# Altium schematic sheet H-Bridge using MOSFET.SchDoc("H-Bridge using MOSFET")
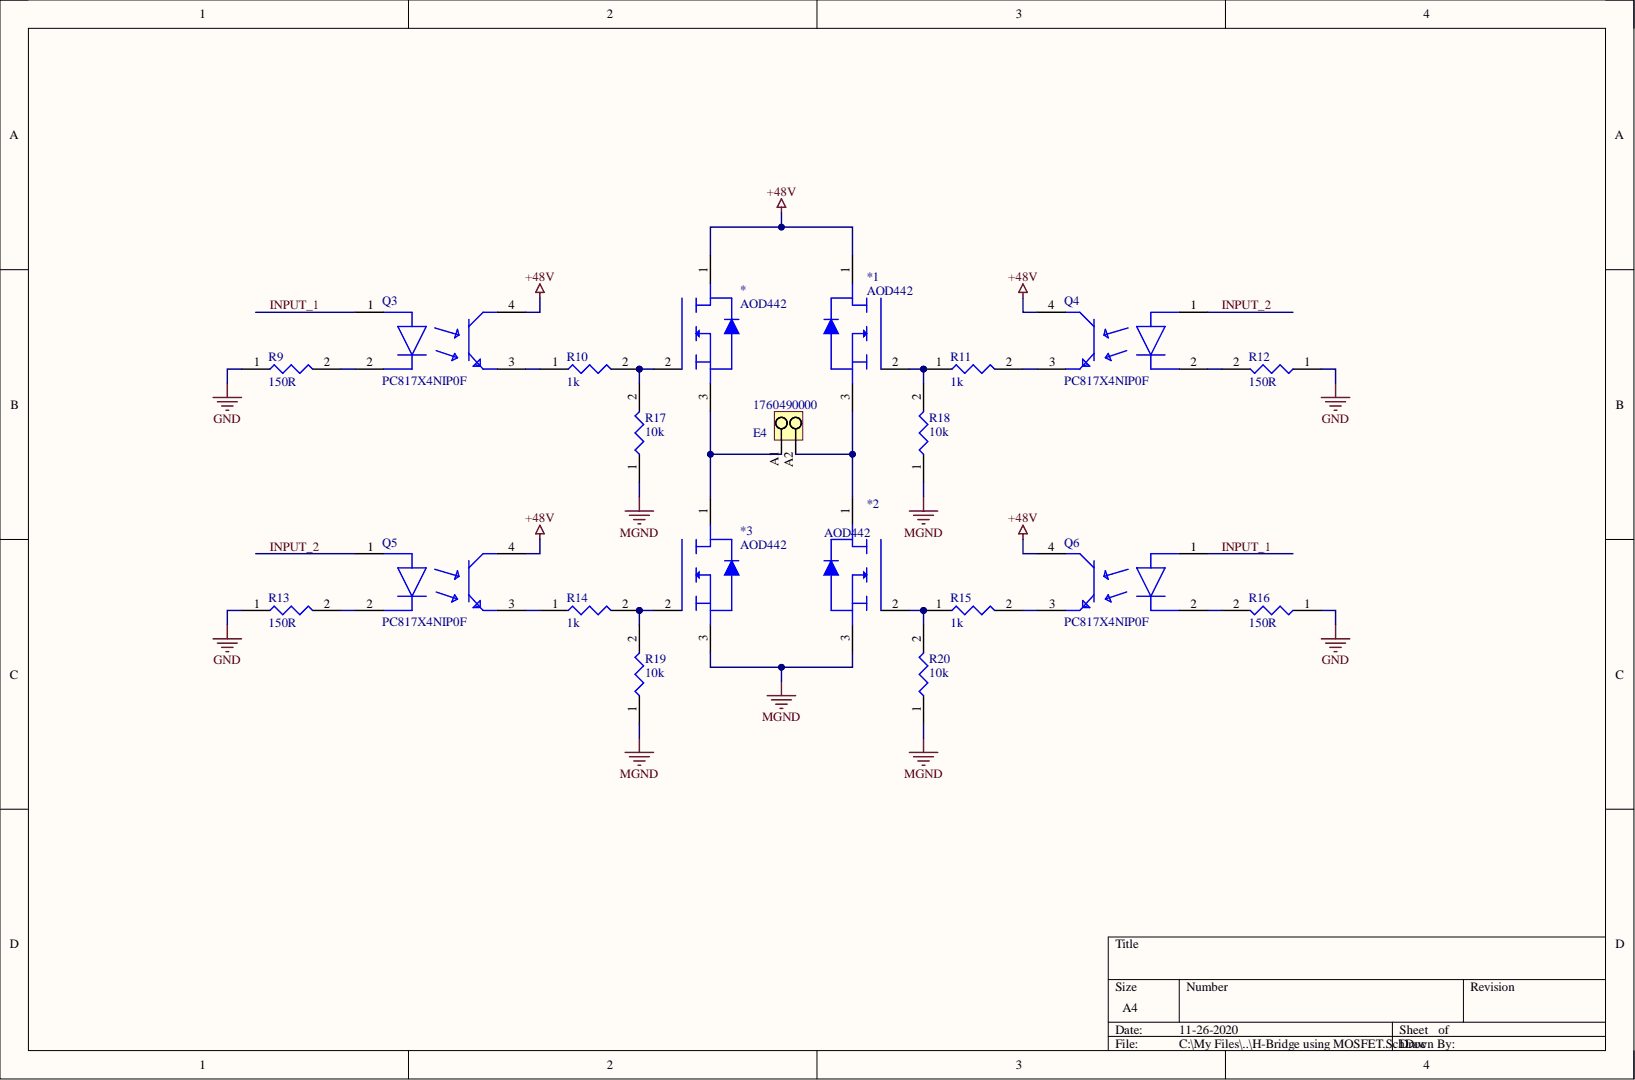
[diagram p0: H-Bridge using MOSFET.SchDoc]

## Parts
*  AOD442
*1  AOD442
*2  AOD442
*3  AOD442
E4  1760490000  [PM_5_08_90_02]
Q3  PC817X4NIP0F  [FP-PC817X4NIP0F-MFG]
Q4  PC817X4NIP0F  [FP-PC817X4NIP0F-MFG]
Q5  PC817X4NIP0F  [FP-PC817X4NIP0F-MFG]
Q6  PC817X4NIP0F  [FP-PC817X4NIP0F-MFG]
R9  150R  [R0603 1k]
R10  1k  [R0603 1k]
R11  1k  [R0603 1k]
R12  150R  [R0603 1k]
R13  150R  [R0603 1k]
R14  1k  [R0603 1k]
R15  1k  [R0603 1k]
R16  150R  [R0603 1k]
R17  10k  [R0603 1k]
R18  10k  [R0603 1k]
R19  10k  [R0603 1k]
R20  10k  [R0603 1k]

## Nets
+48V: *.1 *1.1 Q3.4 Q4.4 Q5.4 Q6.4
GND: R9.1 R12.1 R13.1 R16.1
MGND: *2.3 *3.3 R17.1 R18.1 R19.1 R20.1
INPUT_1: Q3.1 Q6.1
INPUT_2: Q4.1 Q5.1
Net*1_2: *1.2 R11.1 R18.2
Net*1_3: *1.3 *2.1 E4.A2
Net*2_2: *2.2 R15.1 R20.2
Net*3_1: *.3 *3.1 E4.A1
Net*3_2: *3.2 R14.2 R19.2
Net*_2: *.2 R10.2 R17.2
NetQ3_2: Q3.2 R9.2
NetQ3_3: Q3.3 R10.1
NetQ4_2: Q4.2 R12.2
NetQ4_3: Q4.3 R11.2
NetQ5_2: Q5.2 R13.2
NetQ5_3: Q5.3 R14.1
NetQ6_2: Q6.2 R16.2
NetQ6_3: Q6.3 R15.2

## Library data of the parts
E4 = CMP-1559-01627-1: OMNIMATE Signal - series PM; Manufacturer: Weidmueller; Contact_material: Cu-Leg; Contact_surface: tinned; Insulating_material: Wemid (PA); Mounting_onto_the_PCB: Solder connection; No_of_poles: 2; Pitch_inch: 0,2 inch; Pitch_mm: 5,08 mm; Rated_current_use_group_B_UL_1059: 15 A; Rated_voltage_for_surge_voltage_class_pollution_degree_II_2: 600 V; Rated_voltage_use_group_B_UL_1059: 300 V; Short_text_for_material: PM 5.08/02/90 3.5SN OR BX; Side_termination_characteristic: closed side; Solder_pin_length_mm: 3,5 mm; Solid_max_H05_07_V_U: 2,5 mm²; Solid_min_H05_07_V_U: 0,13 mm²; UL_94_flammability_rating: V-0; Wire_cross_section_AWG_max_UL_1059: AWG 14; Wire_cross_section_AWG_min_UL_1059: AWG 26; Colour: Orange; Component Kind: Standard; ComponentLink1Description: Manufacturer URL; ComponentLink1URL: http://www.weidmueller.com/; ComponentLink2Description: URL Link1; ComponentLink2URL: http://catalog.weidmueller.com/catalog/Start.do?localeId=en&ObjectID=1760490000; Library Name: Altium Content Vault; PackageReference: PM_5_08_90_02; Packaging: Box; PartNumber: 1760490000; Qty: 500 PCS; Revision: V1
Q3-Q6 = CMP-65434-000001-1: OPTOISOLATOR 5KV TRANS 4SMD; Manufacturer: Sharp; Collector Emitter Voltage: 80V; Emiiter Collector Voltage: 6V; Forward Current: 20mA; Forward Voltage: 1.2V; Isolation Voltage: 5kVrms; Max Current Transfer Ratio: 600%; Min Current Transfer Ratio: 50%; Power Dissipation: 200mW; Standoff Height: 0.1mm; Automotive: No; Category: Trans; Component Kind: Standard; ComponentLink2Description: Datasheet; ComponentLink2URL: https://www.sharpsde.com/fileadmin/products/Optoelectronics/Isolation%20Devices/Specs%20Photocoupler/PC817XxNIP0F_17Jun08_Spec_ED-04P129A.pdf; Device Class L1: Optoelectronics; Device Class L2: Optocouplers; Device Class L3: unset; Digikey Description: OPTOISOLATOR 5KV TRANS 4SMD; Height: 4.6mm; Lead Free: Yes; Library Name: Altium Content Vault; Manufacturer Part Number: PC817X4NIP0F; Mouser Description: Transistor Output Optocouplers Photocoupler 300-600% CRT; Octopart: https://octopart.com/pc817x4nip0f-sharp-20650453; Package: PC817X4NIP0F; Reverse Voltage: 6V; RoHS: TRUE; Temperature: -30°C to +100°C; Temperature Range High: +100°C; Temperature Range Low: -30°C
R9-R20 = CRGCQ0603F1K0: 0603 1k Ohm 1% 1/10W; Manufacturer: TE Connectivity; Max Power Dissipation: 100mW; Resistance: 1kR; Temperature Coefficient: 100ppm/°C; Case Code (Imperial): 0603; Case Code (Metric): 1608; Case/Package: 0603; Category: Chip SMD Resistors; Component Kind: Standard; ComponentLink1Description: https://www.te.com/commerce/DocumentDelivery/DDEController?Action=srchrtrv&DocNm=1773204-3&DocType=DS&DocLang=English&src-supplier=Digi-Key; ComponentLink1URL: https://www.te.com/commerce/DocumentDelivery/DDEController?Action=srchrtrv&DocNm=1773204-3&DocType=DS&DocLang=English&src-supplier=Digi-Key; ComponentLink2Description: https://4donline.ihs.com/images/VipMasterIC/IC/TYEL/TYEL-S-A0004929575/TYEL-S-A0004929575-1.pdf?hkey=52A5661711E402568146F3353EA87419&src-supplier=Farnell; ComponentLink2URL: https://4donline.ihs.com/images/VipMasterIC/IC/TYEL/TYEL-S-A0004929575/TYEL-S-A0004929575-1.pdf?hkey=52A5661711E402568146F3353EA87419&src-supplier=Farnell; ComponentLink3Description: https://www.te.com/commerce/DocumentDelivery/DDEController?Action=srchrtrv&amp;DocNm=1773204-3&amp;DocType=DS&amp;DocLang=English&src-supplier=Digi-Key; ComponentLink3URL: https://www.te.com/commerce/DocumentDelivery/DDEController?Action=srchrtrv&amp;DocNm=1773204-3&amp;DocType=DS&amp;DocLang=English&src-supplier=Digi-Key; ComponentLink4Description: https://4donline.ihs.com/images/VipMasterIC/IC/TYEL/TYEL-S-A0004929575/TYEL-S-A0004929575-1.pdf?hkey=52A5661711E402568146F3353EA87419&src-supplier=Element14; ComponentLink4URL: https://4donline.ihs.com/images/VipMasterIC/IC/TYEL/TYEL-S-A0004929575/TYEL-S-A0004929575-1.pdf?hkey=52A5661711E402568146F3353EA87419&src-supplier=Element14; ComponentLink5Description: https://4donline.ihs.com/images/VipMasterIC/IC/TYEL/TYEL-S-A0004929575/TYEL-S-A0004929575-1.pdf?hkey=52A5661711E402568146F3353EA87419&src-supplier=Newark; ComponentLink5URL: https://4donline.ihs.com/images/VipMasterIC/IC/TYEL/TYEL-S-A0004929575/TYEL-S-A0004929575-1.pdf?hkey=52A5661711E402568146F3353EA87419&src-supplier=Newark; Features: Moisture Resistant,Automotive AEC-Q200; Manufacturer Part Number: CRGCQ0603F1K0; Max Operating Temperature: 155°C; Min Operating Temperature: -55°C; Number of Terminations: 2; Packaging: Cut Tape; Pricing 1: 1=0.10000, 10=0.02700, 100=0.01090, 1000=0.00490, 2500=0.00426 (USD); Resistor Type: Thick Film; RoHS: No; Stock 1: 37973; Supplier 1: Digi-Key; Supplier Part Number 1: A129688CT-ND; Tolerance: 1%; Library Name: SectionE_BreakOut.SchLib
* *1-*3 = AOD442: Component Kind: Standard; Library Name: Power_MOSFET.SchLib
